# Altium schematic sheet [18]-WIFI_MODULE.SchDoc([18]-WIFI_MODULE)
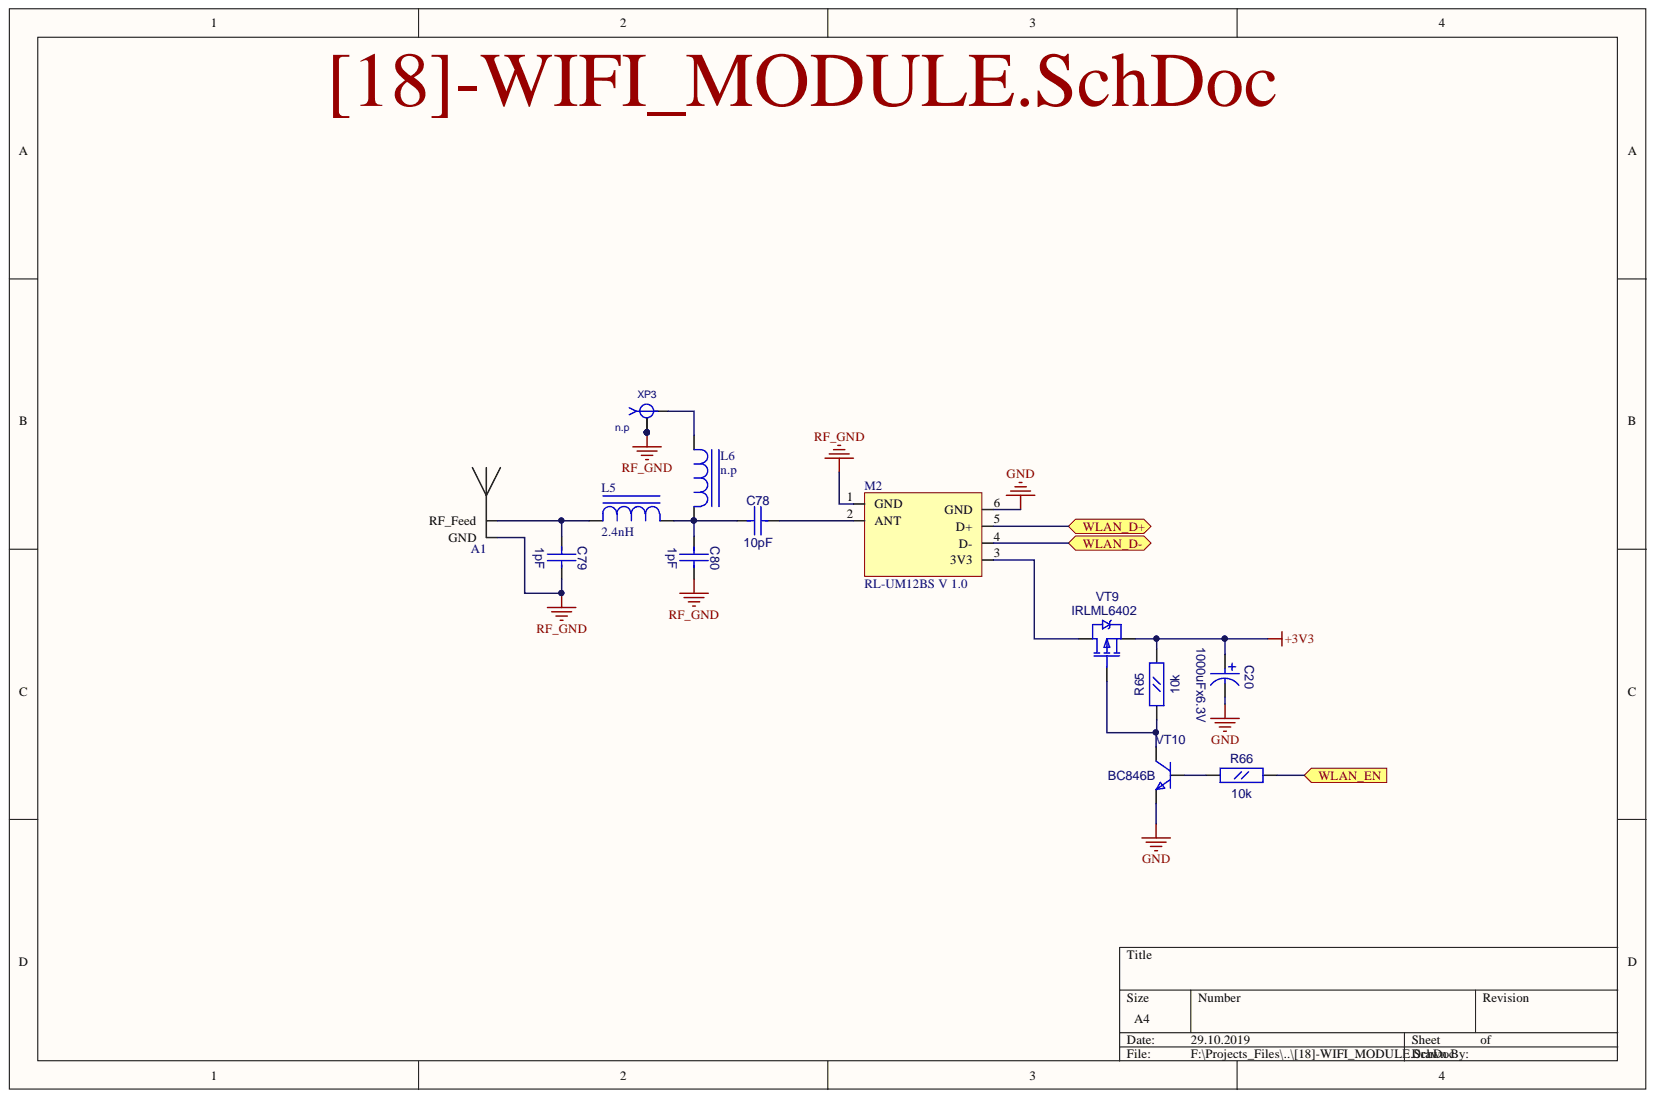
[diagram p0: [18]-WIFI_MODULE.SchDoc]

## Parts
A1  Antenna Wi-Fi  [Antenna_WiFi]  (value not drawn)
C20  1000uFx6.3V  [CC8X11.5_L]
C78  10pF  [CC0603]
C79  1pF  [CC0603]
C80  1pF  [CC0603]
L5  2.4nH  [L0402]
L6  n.p  [L0402]
M2  RL-UM12BS V 1.0  [RTL8188_MODULE]
R65  10k  [RC0603]
R66  10k  [RC0603]
VT9  IRLML6402  [SOT-23(123)]
VT10  BC846B  [SOT-23(123)]
XP3  n.p  [SMA-JR]

## Ports
WLAN_D+, WLAN_D-, WLAN_EN

## Nets
+3V3: C20.1 R65.2 VT9.2
GND: C20.2 M2.6(GND) VT10.2
RF_GND: A1.2 C79.2 C80.2 M2.1(GND) XP3.2 XP3.3 XP3.4 XP3.5
WLAN_EN: R66.2 ; port WLAN_EN
NetA1_1: A1.1 C79.1 L5.1
NetC78_1: C78.1 C80.1 L5.2 L6.2
NetC78_2: C78.2 M2.2(ANT)
NetL6_1: L6.1 XP3.1
NetM2_3: M2.3(3V3) VT9.3
NetM2_4: M2.4(D-)
NetM2_5: M2.5(D+)
NetR65_1: R65.1 VT9.1 VT10.3
NetR66_1: R66.1 VT10.1

## Library data of the parts
A1 = Antenna Wi-Fi: 2.43GHz; Component Kind: Standard; Library Name: fizik_lib.SchLib
C20 = CAP_8mm: Radial capacitor; Value: 1000uFx6.3V; Component Kind: Standard; Library Name: fizik_lib.SchLib
C78-C80 = CC0603: Description: CC0603; Component Kind: Standard; Library Name: gprs_modem_2.SchLib
  C78: Value: 10pF
  C79 C80: Value: 1pF
L5 L6 = L0402: Description: L0402; Component Kind: Standard; Library Name: gprs_modem_2.SchLib
  L5: Value: 2.4nH
  L6: Value: n.p
M2 = RTL8188_MODULE: Value: RL-UM12BS V 1.0; Component Kind: Standard; Library Name: fizik_lib.SchLib
R65 R66 = RC0603: Value: 10k; Description: RC0603; Component Kind: Standard; Library Name: gprs_modem_2.SchLib
VT9 = IRLML6402: HEXFET Power MOSFET; OriginalName: IRLML5103; ComponentField7: Published 8-Jun-2000; Value: IRLML6402; Component Kind: Standard; Library Name: gprs_modem_2.SchLib
VT10 = BC846BLT1: ComponentField7: Published 29-Sep-2000; Value: BC846B; Simulation: BC846BLT1; OriginalName: BC846BLT1; Description: BC846BLT1; Component Kind: Standard; Library Name: gprs_modem_2.SchLib
XP3 = SMA-JR: Description: SMA-JR; Component Kind: Standard; Library Name: fizik_lib.SchLib
